# Altium schematic sheet Deployment.SchDoc(Deployment)
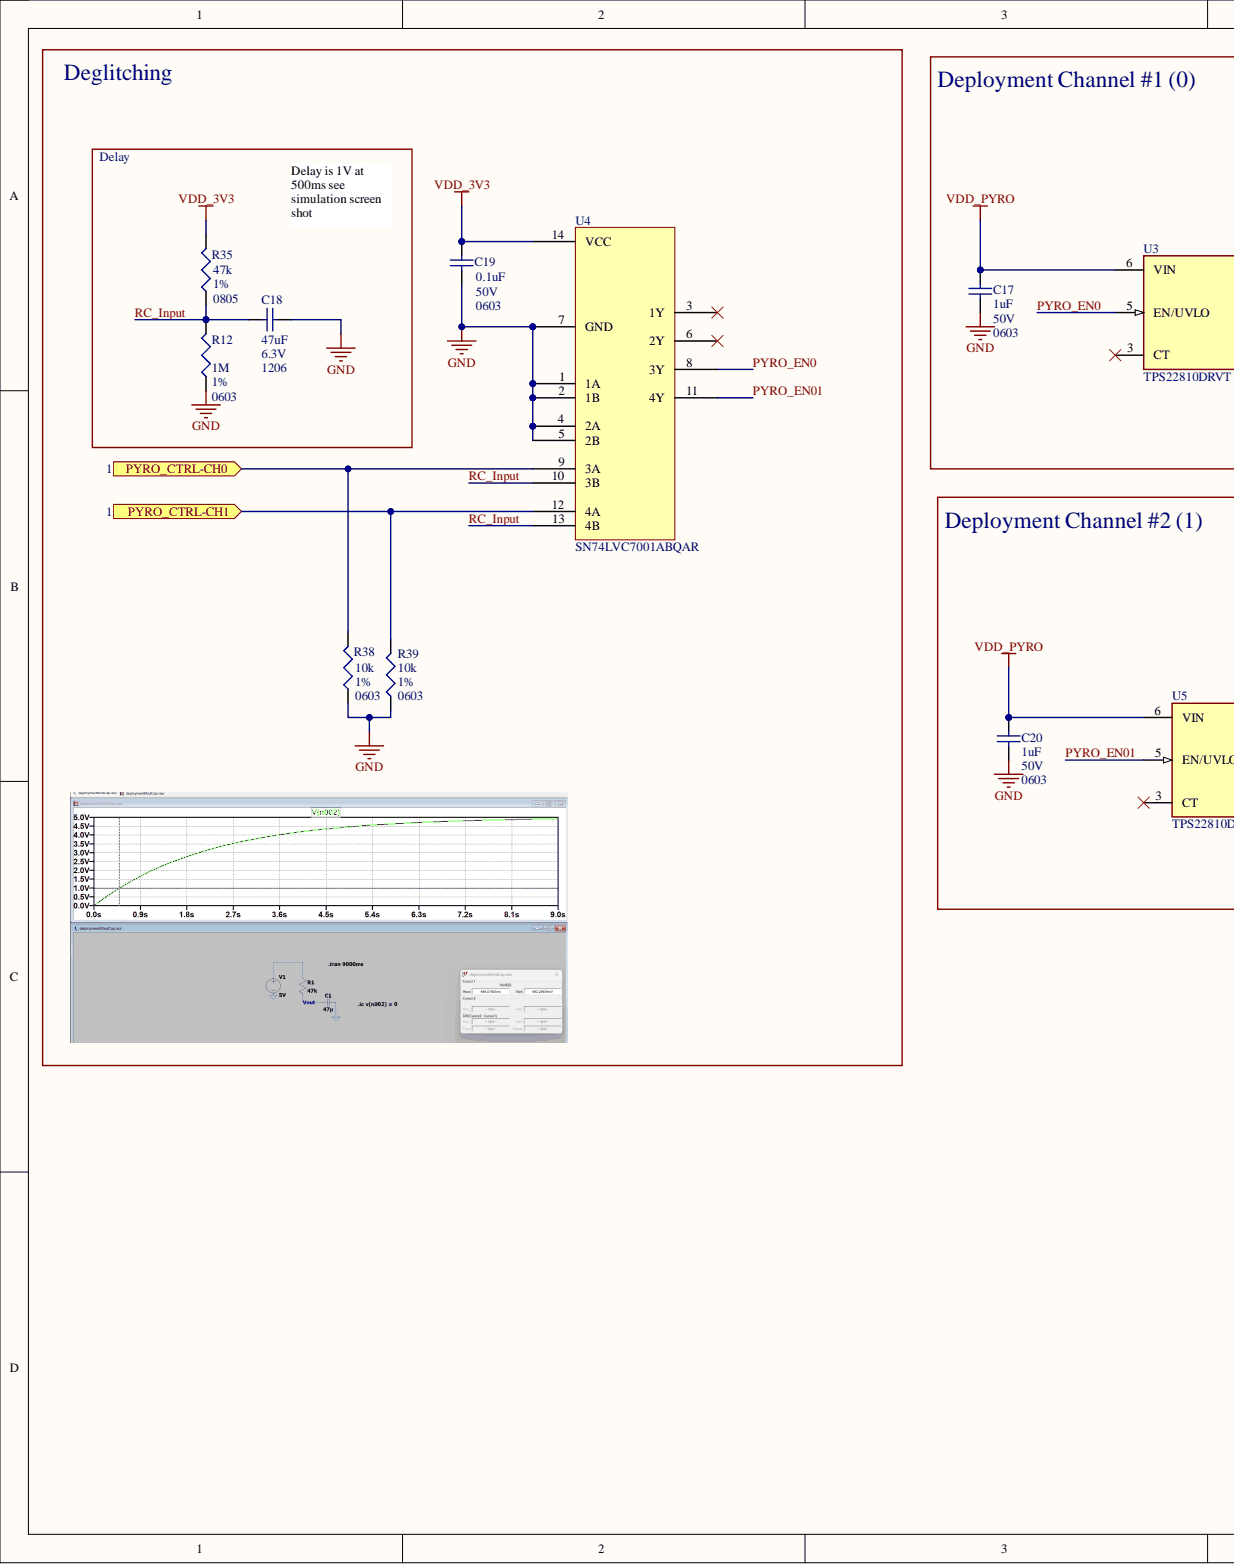
[diagram p0: Deployment.SchDoc, left]
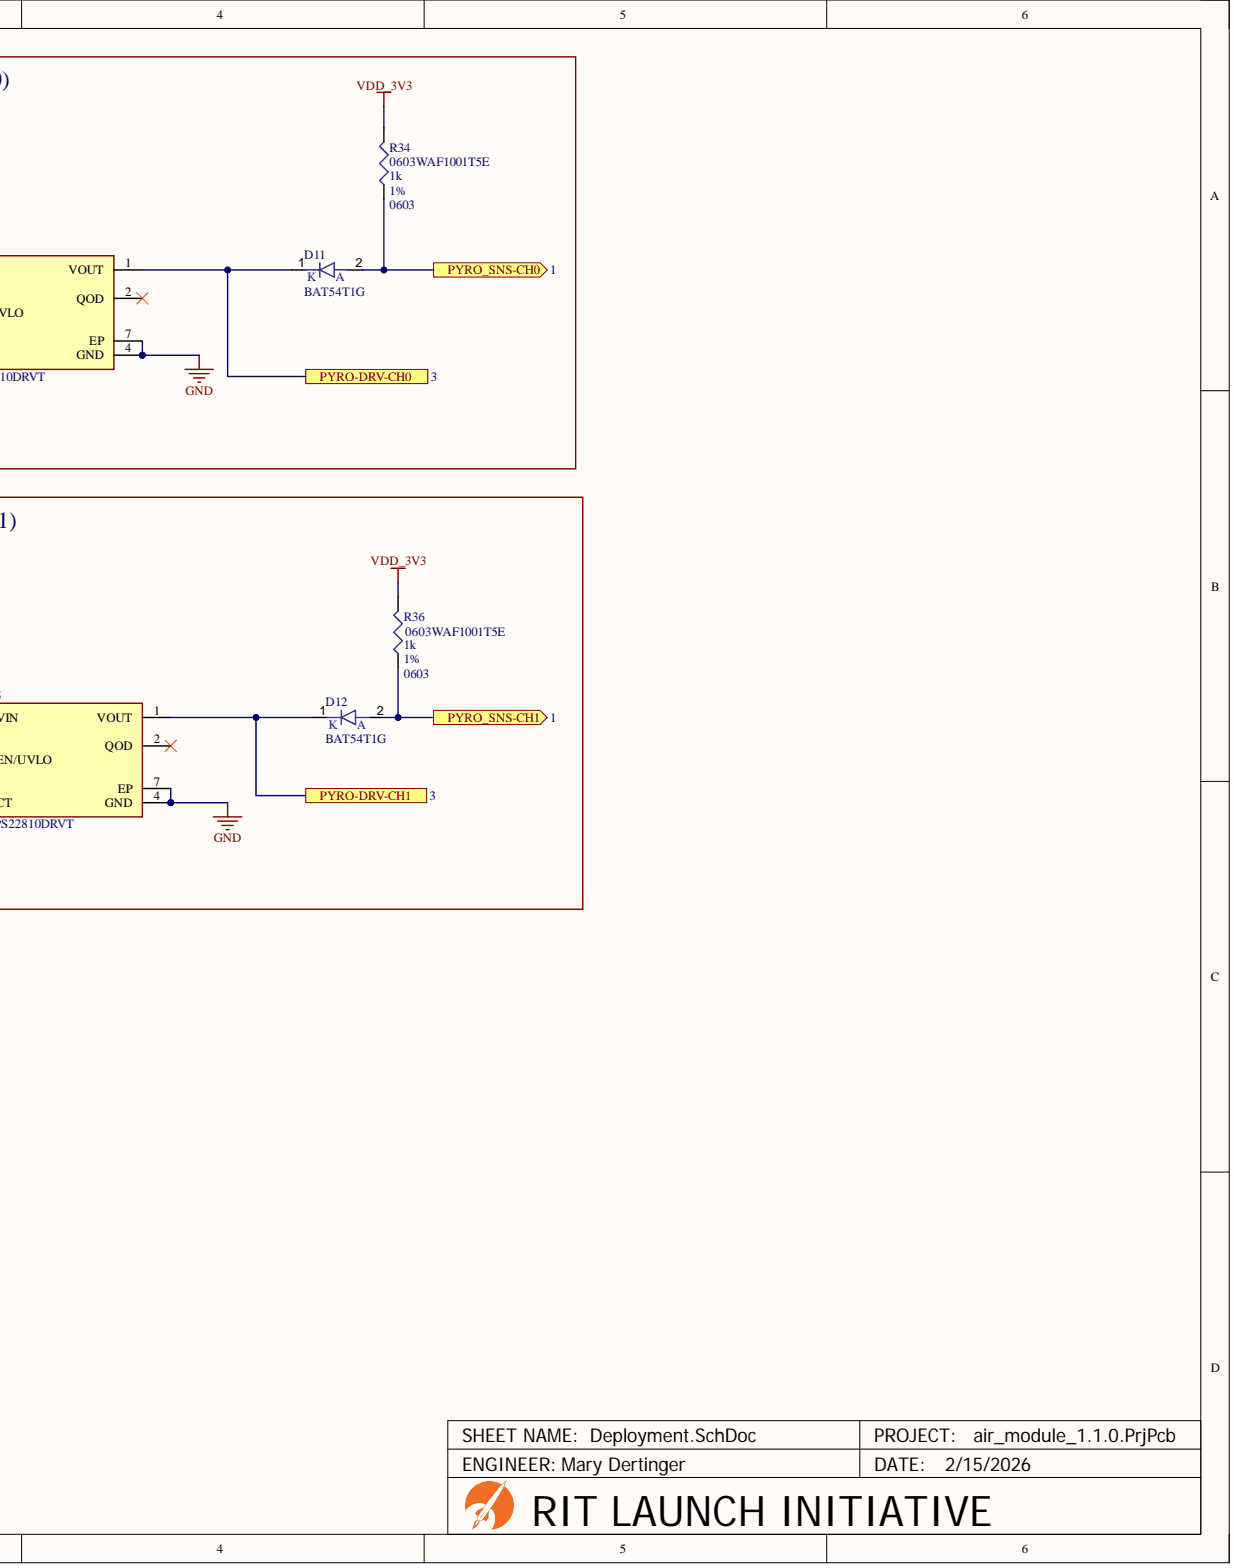
[diagram p1: Deployment.SchDoc, right]

## Parts
C17  Capacitance: 1uF  Case Code (Imperial): 0603  Voltage: 50V  (hidden comment: CL10A105KB8NNNC)
C18  Capacitance: 47uF  Case Code (Imperial): 1206  Voltage: 6.3V  (hidden comment: GRM31CR60J476KE19L)
C19  Capacitance: 0.1uF  Case Code (Imperial): 0603  Voltage: 50V  (hidden comment: CC0603KRX7R9BB104)
C20  Capacitance: 1uF  Case Code (Imperial): 0603  Voltage: 50V  (hidden comment: CL10A105KB8NNNC)
D11  BAT54T1G
D12  BAT54T1G
R12  Case/Package: 0603  Resistance: 1M  Tolerance: 1%  (hidden comment: 0603WAF1004T5E)
R34  0603WAF1001T5E  Case/Package: 0603  Resistance: 1k  Tolerance: 1%
R35  Case/Package: 0805  Resistance: 47k  Tolerance: 1%  (hidden comment: 0805W8F4702T5E)
R36  0603WAF1001T5E  Case/Package: 0603  Resistance: 1k  Tolerance: 1%
R38  Case/Package: 0603  Resistance: 10k  Tolerance: 1%  (hidden comment: 0603WAF1002T5E)
R39  Case/Package: 0603  Resistance: 10k  Tolerance: 1%  (hidden comment: 0603WAF1002T5E)
U3  TPS22810DRVT
U4  SN74LVC7001ABQAR
U5  TPS22810DRVT

## Ports
PYRO-DRV-CH0, PYRO-DRV-CH1, PYRO_CTRL-CH0, PYRO_CTRL-CH1, PYRO_SNS-CH0, PYRO_SNS-CH1

## Nets
GND: C17.1 C18.1 C19.1 C20.1 R12.1 R38.1 R39.1 U3.4(GND) U3.7(EP) U4.1(1A) U4.2(1B) U4.4(2A) U4.5(2B) U4.7(GND) U5.4(GND) U5.7(EP)
VDD_3V3: C19.2 R34.2 R35.2 R36.2 U4.14(VCC)
VDD_PYRO: C17.2 C20.2 U3.6(VIN) U5.6(VIN)
PYRO-DRV-CH0: D11.1 U3.1(VOUT) ; port PYRO-DRV-CH0
PYRO-DRV-CH1: D12.1 U5.1(VOUT) ; port PYRO-DRV-CH1
PYRO_CTRL-CH0: R38.2 U4.9(3A) ; port PYRO_CTRL-CH0
PYRO_CTRL-CH1: R39.2 U4.12(4A) ; port PYRO_CTRL-CH1
PYRO_EN0: U3.5(EN/UVLO) U4.8(3Y)
PYRO_EN01: U4.11(4Y) U5.5(EN/UVLO)
PYRO_SNS-CH0: D11.2 R34.1 ; port PYRO_SNS-CH0
PYRO_SNS-CH1: D12.2 R36.1 ; port PYRO_SNS-CH1
RC_Input: C18.2 R12.2 R35.1 U4.10(3B) U4.13(4B)

Not connected: U3 2(QOD) 3(CT); U4 3(1Y) 6(2Y); U5 2(QOD) 3(CT)

## Library data of the parts
C17 C20 = CMP-001-0307-1: CAP CER 1UF 50V X5R 0603; Tolerance: 10%; Case Code (Metric): 1608; Case/Package: 0603; Component Kind: Standard; Construction: Flat; Contact Plating: Tin; Depth: 0.8mm; Dielectric: X5R; JLCPCB Part #: C15849; Length: 1.6mm; Max Operating Temperature: 85°C; Min Operating Temperature: -55°C; Mounting Technology: Surface Mount; Package Quantity: 1; Packaging: Tape and Reel; Radiation Hardening: No; RoHS Compliant: Yes; Series: CL; Termination: Wraparound; Thickness: 0.035inch; Width: 0.031inch; Library Name: RIT Launch Initiative
C18 = CMP-001-0200-1: CAP CER 47UF 6.3V X5R 1206; Material: Ceramic; Tolerance: 10%; Case Code (Metric): 3216; Case/Package: 1206; Component Kind: Standard; Construction: Flat; Depth: 1.6mm; Dielectric: X5R; Height: 1.6mm; Lead Free: Lead Free; Length: 3.2mm; Max Operating Temperature: 85°C; Min Operating Temperature: -55°C; Mount: Surface Mount; Package Quantity: 1; Packaging: Tape and Reel; Radiation Hardening: No; REACH SVHC: No SVHC; RoHS Compliant: Yes; Series: GRM; Termination: SMD/SMT; Thickness: 0.071inch; Voltage Rating: 6.3V; Voltage Rating (DC): 6.3V; Width: 1.6mm; Library Name: RIT Launch Initiative
C19 = CMP-001-0242-3: CAP CER 0.1UF 50V X7R 0603; Dielectric Material: Ceramic; Tolerance: 10%; Case Code (Metric): 1608; Case/Package: 0603; Component Kind: Standard; Depth: 0.8mm; Dielectric: X7R; Halogen Free: Halogen Free; Height: 0.8mm; JLCPCB Part #: C14663; Lead Free: Lead Free; Length: 0.063inch; Max Operating Temperature: 125°C; Min Operating Temperature: -55°C; Mount: Surface Mount; Number of Pins: 2; Package Quantity: 1; Packaging: Tape and Reel; Radiation Hardening: No; REACH SVHC: No SVHC; RoHS Compliant: Yes; Series: CC; Termination: SMD/SMT; Thickness: 0.035inch; Voltage Rating: 50V; Width: 0.031inch; Library Name: RIT Launch Initiative
D11 D12 = CMP-001-0115-2: DIODE SCHOTTKY 30V 200MA SOD123; Current (A): 0.2; Forward Voltage (V): 0.8; Reverse Voltage (V): 30; Component Kind: Standard; JLCPCB Part #: C152458; Library Name: RIT Launch Initiative
R12 = CMP-001-0252-2: RES 1M OHM 1% 1/10W 0603; Component Kind: Standard; JLCPCB Part #: C22935; Library Name: RIT Launch Initiative
R34 R36 = CMP-001-0287-1: RES 1K OHM 1% 1/10W 0603; Component Kind: Standard; JLCPCB Part #: C21190; RoHS Compliant: Yes; Library Name: RIT Launch Initiative
R35 = CMP-009-00194-1: RES SMD 47K OHM 1% 125mW 0805; Voltage: 150V; Component Kind: Standard; JLCPCB Part #: C17713; Library Name: RIT Launch Initiative
R38 R39 = CMP-001-0268-1: RES 10K OHM 1% 1/10W 0603; Component Kind: Standard; JLCPCB Part #: C25804; RoHS Compliant: Yes; Library Name: RIT Launch Initiative
U3 U5 = CMP-00010-1: Buffer/Inverter Based Peripheral Driver, 1 Driver, PDSO6; Built-in Protections: Under Voltage,TRANSIENT,THERMAL; Channels: 1; Interface IC Type: BUFFER OR INVERTER BASED PERIPHERAL DRIVER; Max Supply Voltage: 18V; Min Supply Voltage: 2.7V; Nominal Supply Voltage: 3.3V; Output Current Flow Direction: SINK; Package Body Material: Plastic; Case/Package: VSON; Component Kind: Standard; Height - Seated (Max): 0.8mm; JESD-30 Code: S-PDSO-N6; JESD-609 Code: e4; Length: 2mm; Max Operating Temperature: 105°C; Min Operating Temperature: -40°C; Moisture Sensitivity Level: 2; Package Shape: Square; Package Style: SMALL OUTLINE, VERY THIN PROFILEMeter; Pins: 6; Reach SVHC Compliant: Yes; RoHS Compliant: Yes; Surface Mount: Yes; Temperature Grade: INDUSTRIAL; Terminal Finish: Nickel; Terminal Form: No Lead; Terminal Pitch: 0.65mm; Terminal Position: DUAL; Width: 2mm; Library Name: RIT Launch Initiative
U4 = CMP-001-0459-2: Quadruple two-input 1.2V to 3.6V AND gates with Schmitt-trigger inputs 14-WQFN -40 to 125; Component Kind: Standard; Library Name: RIT Launch Initiative
